# Altium schematic sheet 07 - USB Interface.SchDoc
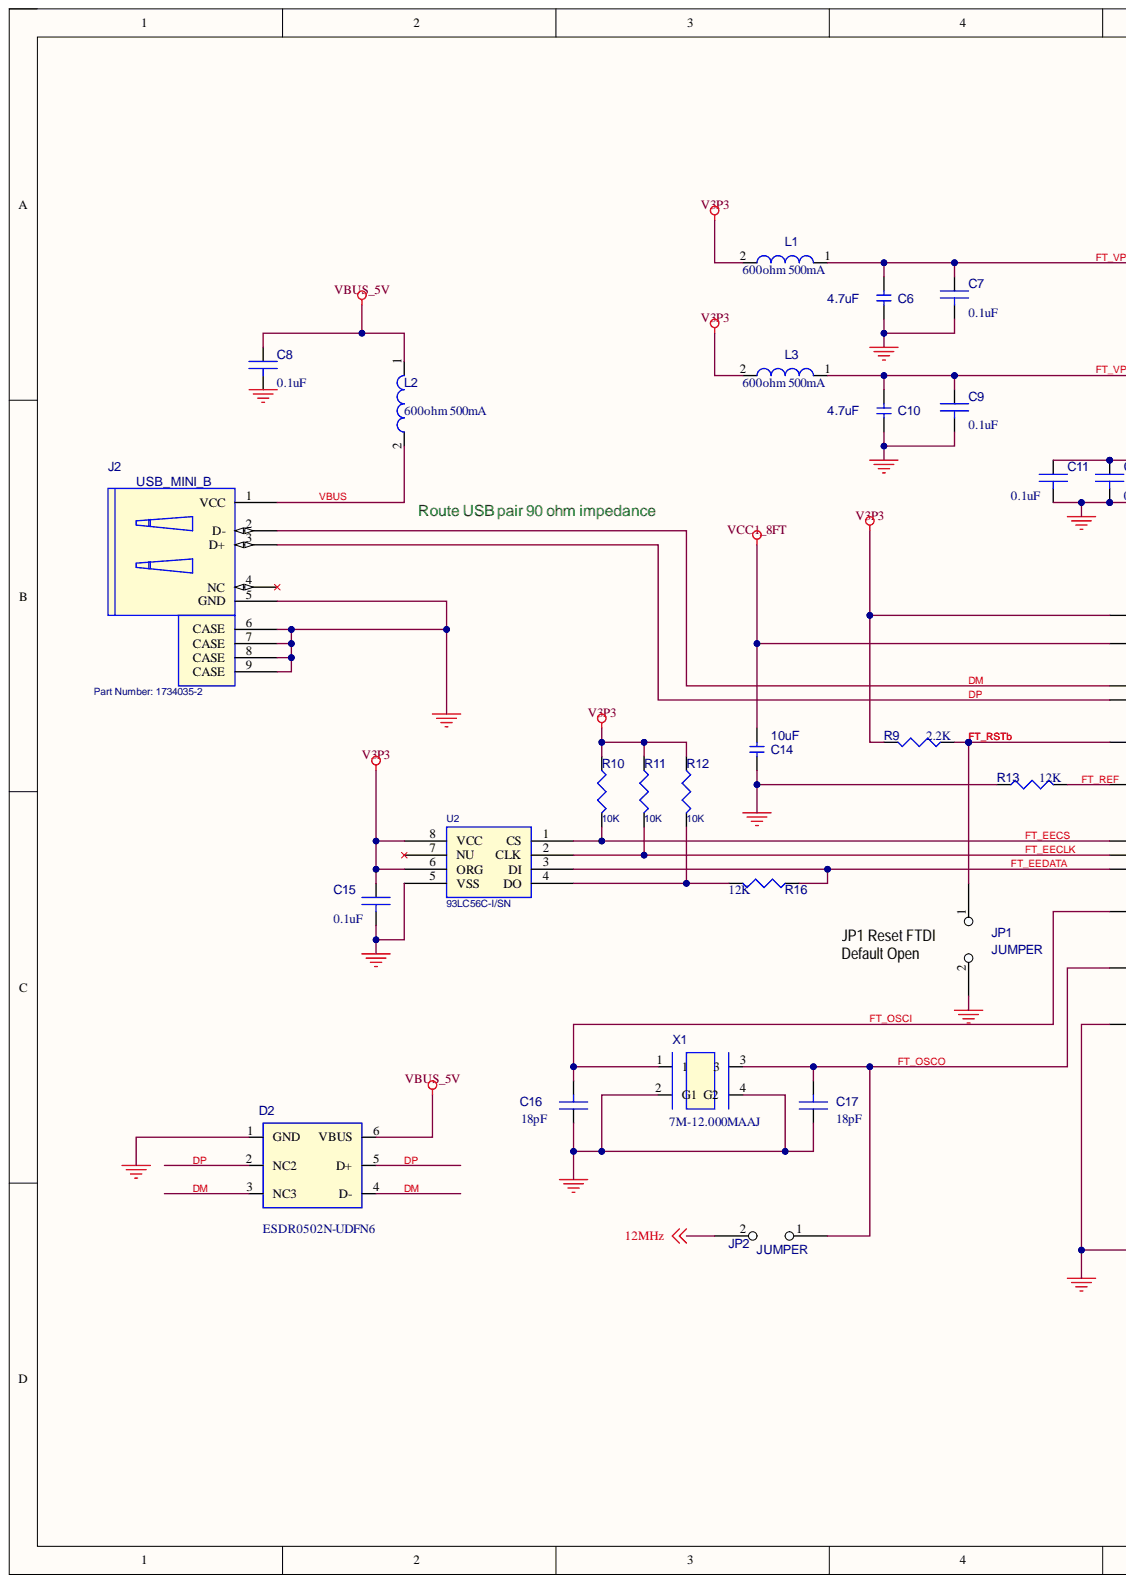
[diagram p0: 07 - USB Interface.SchDoc, left]
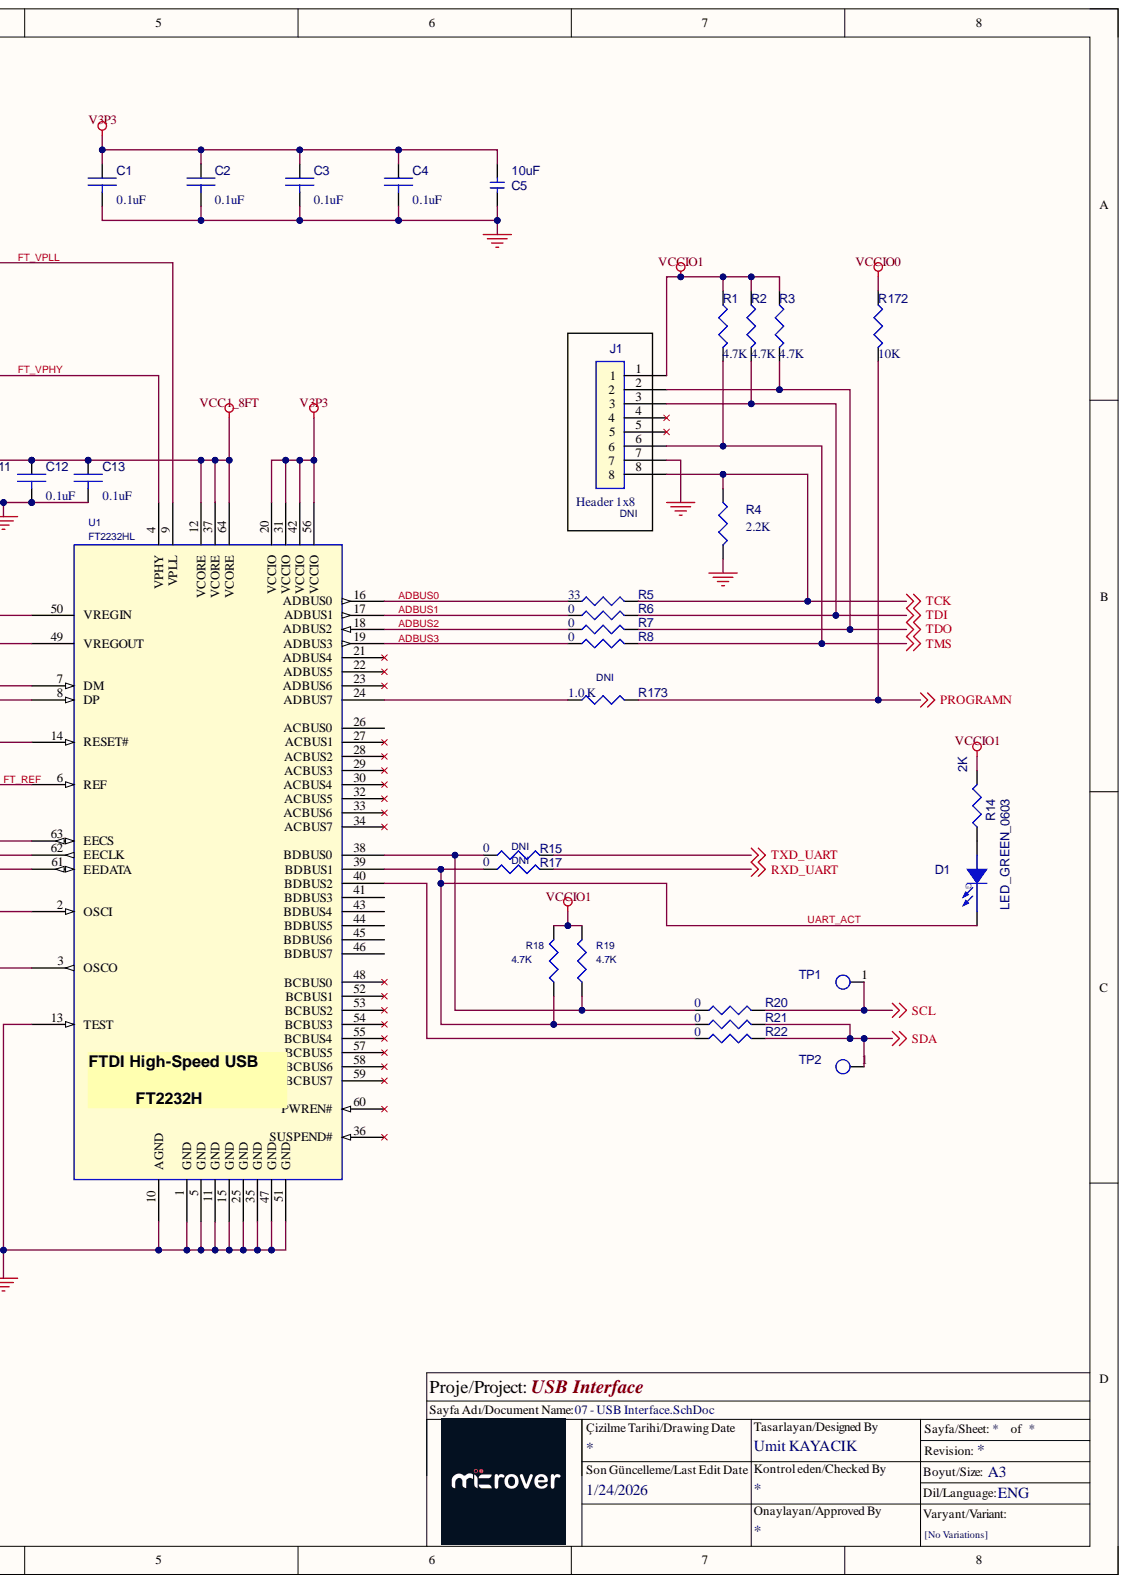
[diagram p1: 07 - USB Interface.SchDoc, right]

## Parts
C1  0.1uF  Part Reference: C1  [C0201]
C2  0.1uF  Part Reference: C2  [C0201]
C3  0.1uF  Part Reference: C3  [C0201]
C4  0.1uF  Part Reference: C4  [C0201]
C5  10uF  Part Reference: C5  [C0603]
C6  4.7uF  Part Reference: C6  [C0603]
C7  0.1uF  Part Reference: C7  [C0201]
C8  0.1uF  Part Reference: C8  [C0201]
C9  0.1uF  Part Reference: C9  [C0201]
C10  4.7uF  Part Reference: C10  [C0603]
C11  0.1uF  Part Reference: C11  [C0201]
C12  0.1uF  Part Reference: C12  [C0201]
C13  0.1uF  Part Reference: C13  [C0201]
C14  10uF  Part Reference: C14  [C0603]
C15  0.1uF  Part Reference: C15  [C0201]
C16  18pF  Part Reference: C16  [C0402]
C17  18pF  Part Reference: C17  [C0402]
D1  LED_GREEN_0603  Part Reference: D1  [APT1608]
D2  ESDR0502N-UDFN6  Part Reference: D2  [UDFN6_040]
J1  Header 1x8  Assy: DNI  Part Reference: J1  [hdr_amp_87220_8_1x8_100]
J2  USB_MINI_B  Manufacturer Part Number: 1734035-2  Part Reference: J2  [USB_MINI_B-1734035-2]
JP1  JUMPER  Part Reference: JP1  [Header_1x2]
JP2  JUMPER  Part Reference: JP2  [Header_1x2]
L1  600ohm 500mA  Part Reference: L1  [FB0603]
L2  600ohm 500mA  Part Reference: L2  [FB0603]
L3  600ohm 500mA  Part Reference: L3  [FB0603]
R1  4.7K  Part Reference: R1  [R0603]
R2  4.7K  Part Reference: R2  [R0603]
R3  4.7K  Part Reference: R3  [R0603]
R4  2.2K  Part Reference: R4  [R0603]
R5  33  Part Reference: R5  [R0402]
R6  0  Part Reference: R6  [R0603]
R7  0  Part Reference: R7  [R0603]
R8  0  Part Reference: R8  [R0603]
R9  2.2K  Part Reference: R9  [R0603]
R10  10K  Part Reference: R10  [R0603]
R11  10K  Part Reference: R11  [R0603]
R12  10K  Part Reference: R12  [R0603]
R13  12K  Part Reference: R13  [R0603]
R14  2K  Part Reference: R14  [RLP-101]
R15  0  Assy: DNI  Part Reference: R15  [R0603]
R16  12K  Part Reference: R16  [R0603]
R17  0  Assy: DNI  Part Reference: R17  [R0603]
R18  4.7K  Part Reference: R18  [RLP-101]
R19  4.7K  Part Reference: R19  [RLP-101]
R20  0  Part Reference: R20  [R0603]
R21  0  Part Reference: R21  [R0603]
R22  0  Part Reference: R22  [R0603]
R172  10K  Part Reference: R172  [R0603]
R173  1.0 K  Assy: DNI  Part Reference: R173  [R0603]
TP1  Part Reference: TP1  [tp_s_40_63]  (hidden comment: TP_S_40_63)
TP2  Part Reference: TP2  [tp_s_40_63]  (hidden comment: TP_S_40_63)
U1  FT2232HL  Manufacturer: FTDI  Part Reference: U1  [tqfp64_0p5_12p2x12p2_h1p6]
U2  93LC56C-I/SN  Part Reference: U2  [so8_50_244]
X1  7M-12.000MAAJ  Part Reference: X1  [xtal_4p_7m]

## Nets
12MHz: JP2.2
GND: C1.2 C2.2 C3.2 C4.2 C5.1 C6.1 C7.2 C8.2 C9.2 C10.1 C11.2 C12.2 C13.2 C14.1 C15.2 C16.1 C17.1 D2.1(GND) J1.7 J2.5(GND) J2.6(CASE) J2.7(CASE) J2.8(CASE) J2.9(CASE) JP1.2 R4.2 R13.1 U1.1(GND) U1.5(GND) U1.10(AGND) U1.11(GND) U1.13(TEST) U1.15(GND) U1.25(GND) U1.35(GND) U1.47(GND) U1.51(GND) U2.5(VSS) X1.2(G1) X1.4(G2)
PROGRAMN: R172.2 R173.2
RXD_UART: R17.2
SCL: R20.2 TP1.1
SDA: R21.2 R22.2 TP2.1
TCK: J1.8 R4.1 R5.2
TDI: J1.3 R2.2 R6.2
TDO: J1.2 R3.2 R7.2
TMS: J1.6 R1.2 R8.2
TXD_UART: R15.2
V3P3: C1.1 C2.1 C3.1 C4.1 C5.2 C15.1 L1.2 L3.2 R9.2 R10.1 R11.1 R12.1 U1.20(VCCIO) U1.31(VCCIO) U1.42(VCCIO) U1.50(VREGIN) U1.56(VCCIO) U2.6(ORG) U2.8(VCC)
VBUS_5V: C8.1 D2.6(VBUS) L2.1
VCC1_8FT: C11.1 C12.1 C13.1 C14.2 U1.12(VCORE) U1.37(VCORE) U1.49(VREGOUT) U1.64(VCORE)
VCCIO0: R172.1
VCCIO1: J1.1 R1.1 R2.1 R3.1 R14.2 R18.2 R19.2
ADBUS0: R5.1 U1.16(ADBUS0)
ADBUS1: R6.1 U1.17(ADBUS1)
ADBUS2: R7.1 U1.18(ADBUS2)
ADBUS3: R8.1 U1.19(ADBUS3)
DM: D2.3(NC3) D2.4(D-) J2.2(D-) U1.7(DM)
DP: D2.2(NC2) D2.5(D+) J2.3(D+) U1.8(DP)
FT_EECLK: R11.2 U1.62(EECLK) U2.2(CLK)
FT_EECS: R10.2 U1.63(EECS) U2.1(CS)
FT_EEDATA: R16.1 U1.61(EEDATA) U2.3(DI)
FT_OSCI: C16.2 U1.2(OSCI) X1.1
FT_OSCO: C17.2 JP2.1 U1.3(OSCO) X1.3
FT_REF: R13.2 U1.6(REF)
FT_RSTb: JP1.1 R9.1 U1.14(RESET#)
FT_VPHY: C9.1 C10.2 L3.1 U1.4(VPHY)
FT_VPLL: C6.2 C7.1 L1.1 U1.9(VPLL)
UART_ACT: D1.1 R17.1 R18.1 R21.1 U1.39(BDBUS1)
VBUS: J2.1(VCC) L2.2
NetD1_2: D1.2 R14.1
NetR12_2: R12.2 R16.2 U2.4(DO)
NetR15_1: R15.1 R19.1 R20.1 U1.38(BDBUS0)
NetR22_1: R22.1 U1.40(BDBUS2)
NetR173_1: R173.1 U1.24(ADBUS7)

Not connected: J1 4 5; J2 4(NC); U1 21(ADBUS4) 22(ADBUS5) 23(ADBUS6) 26(ACBUS0) 27(ACBUS1) 28(ACBUS2) 29(ACBUS3) 30(ACBUS4) 32(ACBUS5) 33(ACBUS6) 34(ACBUS7) 36(SUSPEND#) 41(BDBUS3) 43(BDBUS4) 44(BDBUS5) 45(BDBUS6) 46(BDBUS7) 48(BCBUS0) 52(BCBUS1) 53(BCBUS2) 54(BCBUS3) 55(BCBUS4) 57(BCBUS5) 58(BCBUS6) 59(BCBUS7) 60(PWREN#); U2 7(NU)

## Library data of the parts
C1-C4 C7-C9 C11-C13 C15 = 0u1_0402: CAP CER 0.1UF 25V 10% X5R 0201; Manufacturer: Murata; Manufacturer Part Number: GRM033R61E104KE14J; Class: Discrete; Assy: -; Component Kind: Standard; Digikey P/N: 399-3521-2-ND; PCB Footprint: C0201; Value: 0.1uF
C5 C6 C10 C14 = C: CURRENT: CIMAX; DIST: FLAT; KNEE: CBMAX; MAX_TEMP: CTMAX; Populate: <Populate>; PSpiceTemplate: C^@REFDES %1 %2 ?TOLERANCE|C^@REFDES| @VALUE ?IC/IC=@IC/ ?TOLERANCE|\n.model C^@REFDES CAP C=1 DEV=@TOLERANCE%|; SLOPE: CSMAX; VOLTAGE: CMAX; Assy: -; Component Kind: Standard; PCB Footprint: C0603; Simulation: C
  C5 C14: Value: 10uF
  C6 C10: Value: 4.7uF
C16 C17 = 0u1_0402: CAP CER 18PF 25V 10% NP0 0402; Manufacturer: Kemet; Manufacturer Part Number: C0402C180K3GACTU; Class: Discrete; Assy: -; Component Kind: Standard; Digikey P/N: C0402C180K3GACTU-ND; PCB Footprint: C0402; Value: 18pF
D1 = LED-SMT1206_GREEN_1: Assy: -; Component Kind: Standard; PCB Footprint: APT1608; Value: LED_GREEN_0603
D2 = ESDR0502: ESD Protection - SLP2510P8 package 8-PIN; ALS LIB: L0000; CLASS: IC; MFG: Semtech; MFG P/N: RClamp0524P; Vendor: Digikey; Vendor P/N: RCLAMP0524PTR-ND; Assy: -; Component Kind: Standard; PCB Footprint: UDFN6_040; Value: ESDR0502N-UDFN6
J1 = header1x8_100: CONN HEADER 8POS .100 VERT TIN; Manufacturer: Molex; Manufacturer Part Number: 0022284081; Class: IO; Component Kind: Standard; Digikey P/N: WM50017-08-ND; PCB Footprint: hdr_amp_87220_8_1x8_100; Value: Header 1x8
J2 = USB_MINI_B_0: CONN RCPT USB2.0 MINI B SMD R/A; Manufacturer: TE Connectivity AMP Connectors; COMPFIXED: NO; COMPGROUP: 0; COMPHEIGHT: 0.000; COMPLOC: [370.000,2590.000]; COMPROT: 270; COMPSIDE: TOP; FPLIST: TYPE_B; Populate: <Populate>; Assy: -; COMPKEY: NO; COMPLOCKED: NO; Component Kind: Standard; DECOUPLER: NO; MEMORY: NO; Part Number: 1734035-2; PCB Footprint: USB_MINI_B-1734035-2; Value: USB_MINI_B
JP1 JP2 = JUMPER_0: Assy: -; Component Kind: Standard; PCB Footprint: Header_1x2; Value: JUMPER
L1-L3 = FB0603: FERRITE CHIP 600 OHM 500MA 0603; Manufacturer: Murata; Manufacturer Part Number: BLM18AG601SN1D; Class: Discrete; Assy: -; Component Kind: Standard; Digikey P/N: 490-1014-1-ND; PCB Footprint: fb0603; Value: 600ohm 500mA
R1-R3 = 5k1_0402: RES 4.70K OHM 1/10W 1% 0603 SMD; Manufacturer: Vishay; Manufacturer Part Number: CRCW06034K70FKEA; Class: Discrete; Assy: -; Component Kind: Standard; Digikey P/N: 541-4.70KHTR-ND; PCB Footprint: R0603; Value: 4.7K
R4 R9 = 5k1_0402: Manufacturer: Vishay; Manufacturer Part Number: CRCW06032K20FKEA; Class: Discrete; Assy: -; Component Kind: Standard; Digikey P/N: 541-2.20KHTR-ND; PCB Footprint: R0603; Value: 2.2K
R5 = 0R_0603: RES SMD 33 OHM 1% 1/10W 0402; Manufacturer: Panasonic; Manufacturer Part Number: ERJ-2RKF33R0X; Class: Discrete; Assy: -; Component Kind: Standard; Digikey P/N: 311-0.0GRTR-ND; PCB Footprint: R0402; Value: 33
R6-R8 R20-R22 = 0R_0603: RES 0.0 OHM 1/10W JUMP 0603 SMD; Manufacturer: Yageo; Manufacturer Part Number: RC0603JR-070RL; Class: Discrete; Assy: -; Component Kind: Standard; Digikey P/N: 311-0.0GRTR-ND; PCB Footprint: R0603; Value: 0
R10-R12 = RES_10K_1W16_0402_5P: RES 10K OHM 1/10W 5% 0603; Manufacturer: Stackpole Electronics Inc; Manufacturer Part Number: RMCF0603JT10K0; DIST: FLAT; MAX_TEMP: RTMAX; MFG P/N: RMCF0402JT10K0; TC1: 0; Total Area(sq-mm): 0.5; Unit Price (USD): 0.02; Assy: -; Component Kind: Standard; Digikey P/N: RMCF0603JT10K0TR-ND; Length(mm): 1; MFG: Stackpole Electronics Inc; PCB Footprint: R0603; TC2: 0; Value: 10K; Wattage: 1/10W; Width(mm): 0.5
R13 R16 = 10k_0402: RES 12K OHM 1/10W 1% 0603 SMD; Manufacturer: Yageo; Manufacturer Part Number: RC0603FR-0712KL; Class: Discrete; Assy: -; Component Kind: Standard; Digikey P/N: 311-12.0KHRTR-ND; PCB Footprint: R0603; Tolerance: 1%; Value: 12K
R14 = RES_0: Assy: -; Component Kind: Standard; PCB Footprint: RLP-101; Value: 2K
R15 R17 = 0R_0603: Res 1/10W 0.0 Ohm 5% 0603; Manufacturer: Yageo; Manufacturer Part Number: RC0603JR-070RL; Class: Discrete; Component Kind: Standard; Digikey P/N: 311-0.0GRTR-ND; PCB Footprint: R0603; Value: 0
R18 R19 = RESISTOR: Populate: <Populate>; ASSY: -; Component Kind: Standard; PCB Footprint: RLP-101; Value: 4.7K
R172 = 5k1_0402: RES SMD 10K OHM 1% 1/10W 0603; Manufacturer: Yageo; Manufacturer Part Number: RC0603FR-0710KL; Class: Discrete; Assy: -; Component Kind: Standard; Digikey P/N: 541-4.70KHTR-ND; PCB Footprint: R0603; Value: 10K
R173 = 0R_0603: RES SMD 1K OHM 1% 1/10W 0603; Manufacturer: Yageo; Manufacturer Part Number: RC0603FR-071KL; Class: Discrete; Component Kind: Standard; Digikey P/N: 311-0.0GRTR-ND; PCB Footprint: R0603; Value: 1.0 K
TP1 TP2 = TP_S_40_63: Square test point, 40mil inner diameter, 63mil outer diameter; Assy: DNI; Class: IO; PCB Footprint: TP; Component Kind: Standard; Value: TP_S_40_63
U1 = FT2232HL: IC USB HS DUAL UART/FIFO 64-LQFP; Assy: Customer Supplied; Class: IC; Component Kind: Standard; Digikey P/N: 768-1024-1-ND; Manufacturer Part Number: FT2232HL; PCB Footprint: tqfp64_0p5_12p2x12p2_h1p6; Value: FT2232HL
U2 = 93LC56_SO8_0: IC EEPROM 2KBIT 3MHZ 8SOIC; Manufacturer: Microchip; Assy: -; Class: IC; Component Kind: Standard; Digikey P/N: 93LC56C-I/SN-ND; Manufacturer Part Number: 93LC56C-I/SN; PCB Footprint: so8_50_244; Value: 93LC56C-I/SN
X1 = crystal-3p2x2p5: CRYSTAL 12MHZ 18PF SMD; Manufacturer: TXC; Manufacturer Part Number: 7M-12.000MAAJ-T; Class: IC; Assy: -; Component Kind: Standard; Digikey P/N: 887-1121-2-ND; PCB Footprint: xtal_4p_7m; Value: 7M-12.000MAAJ
